# Altium schematic sheet LED_Readout_and_Warning_Lights.SchDoc
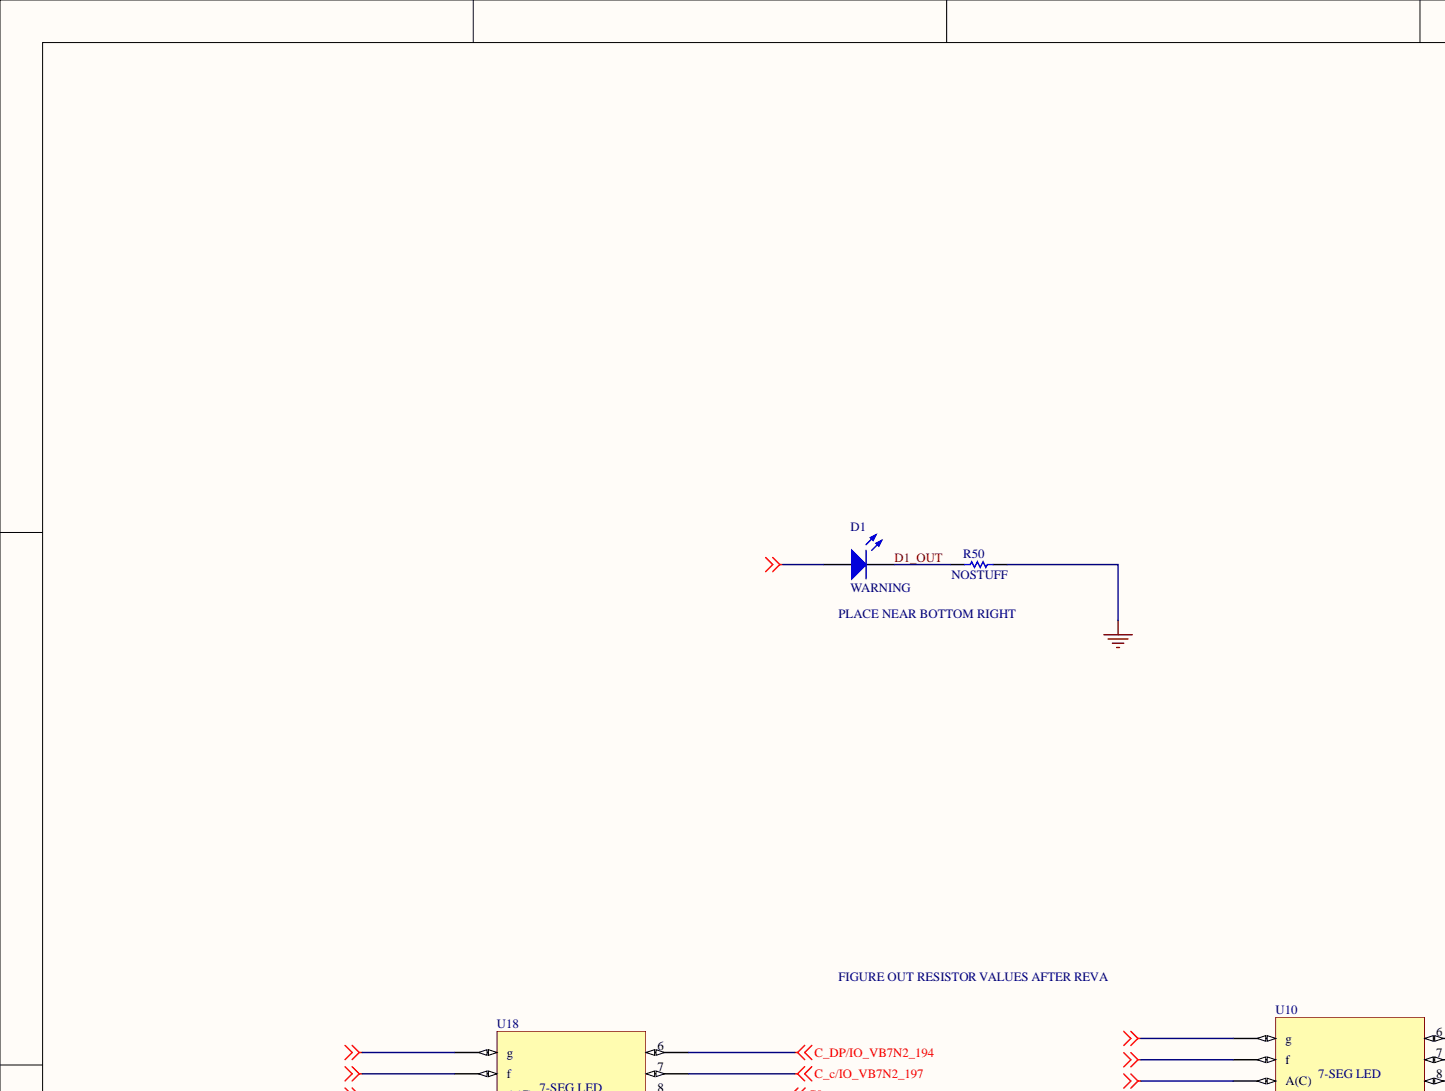
[diagram p0: LED_Readout_and_Warning_Lights.SchDoc, top left]
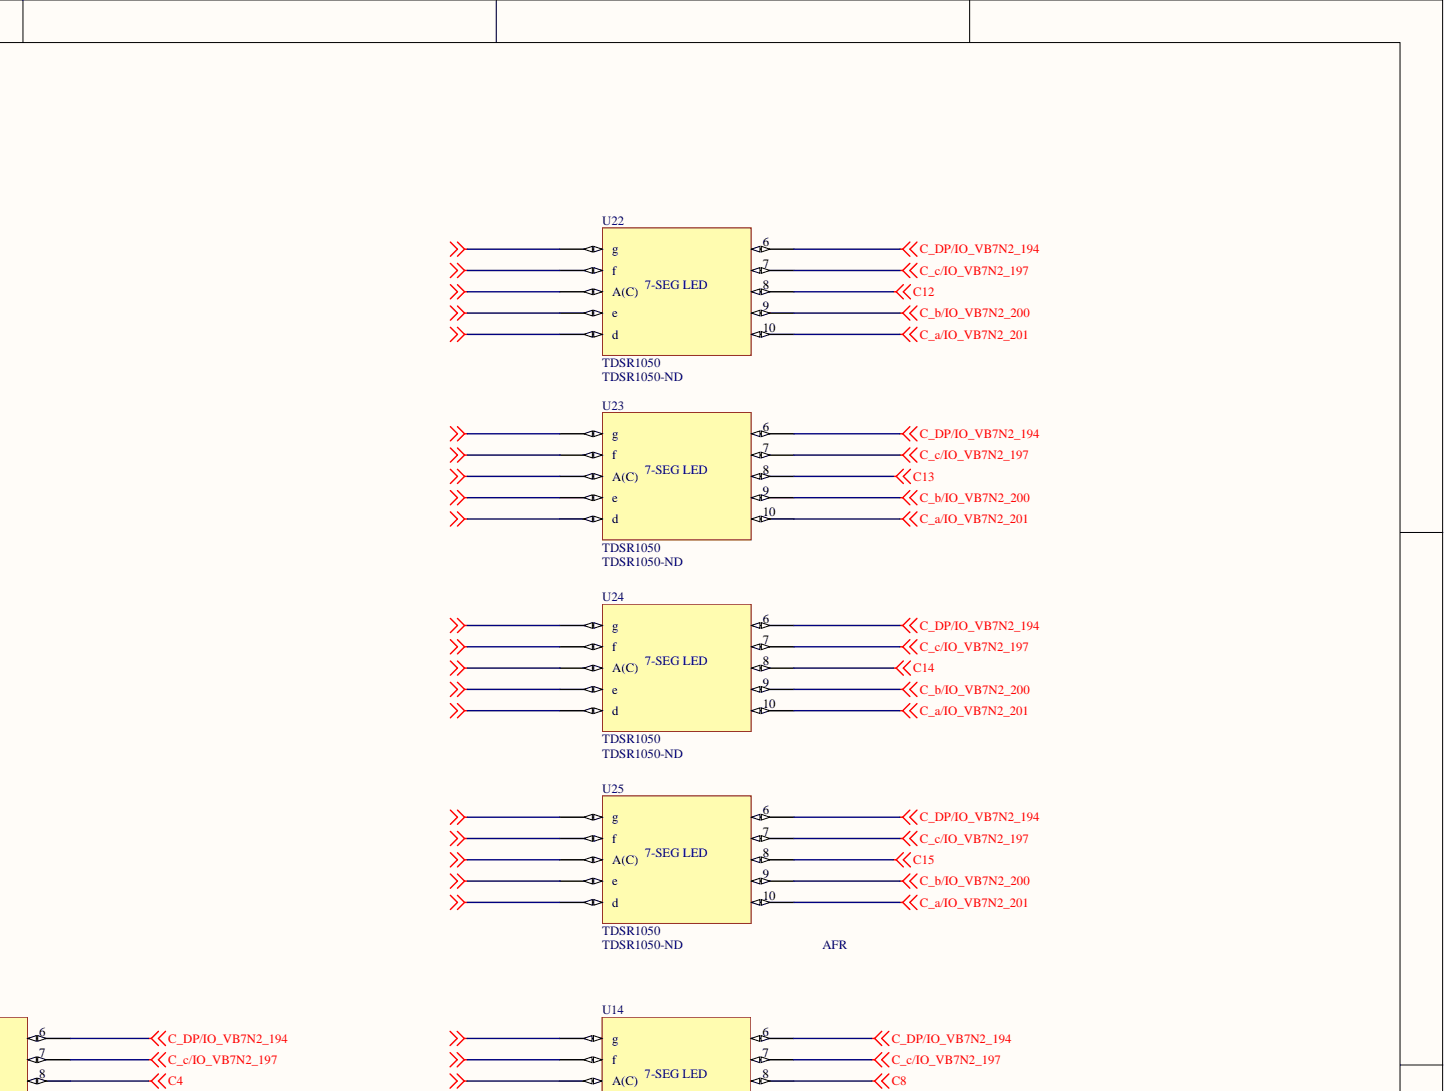
[diagram p1: LED_Readout_and_Warning_Lights.SchDoc, top right]
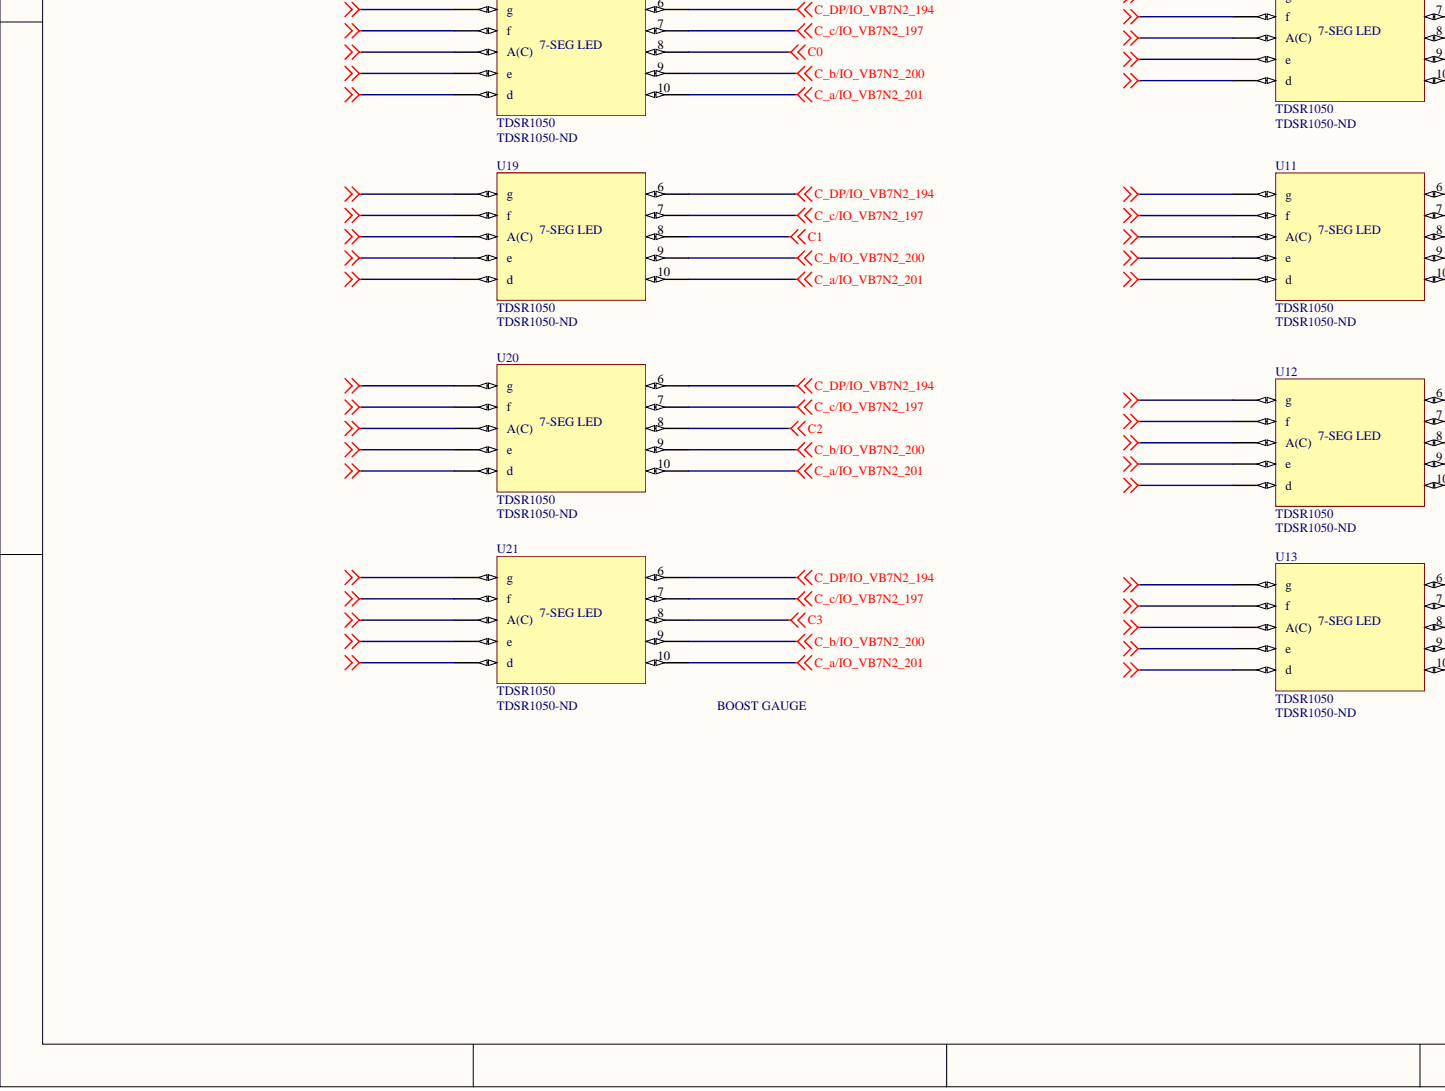
[diagram p2: LED_Readout_and_Warning_Lights.SchDoc, bottom left]
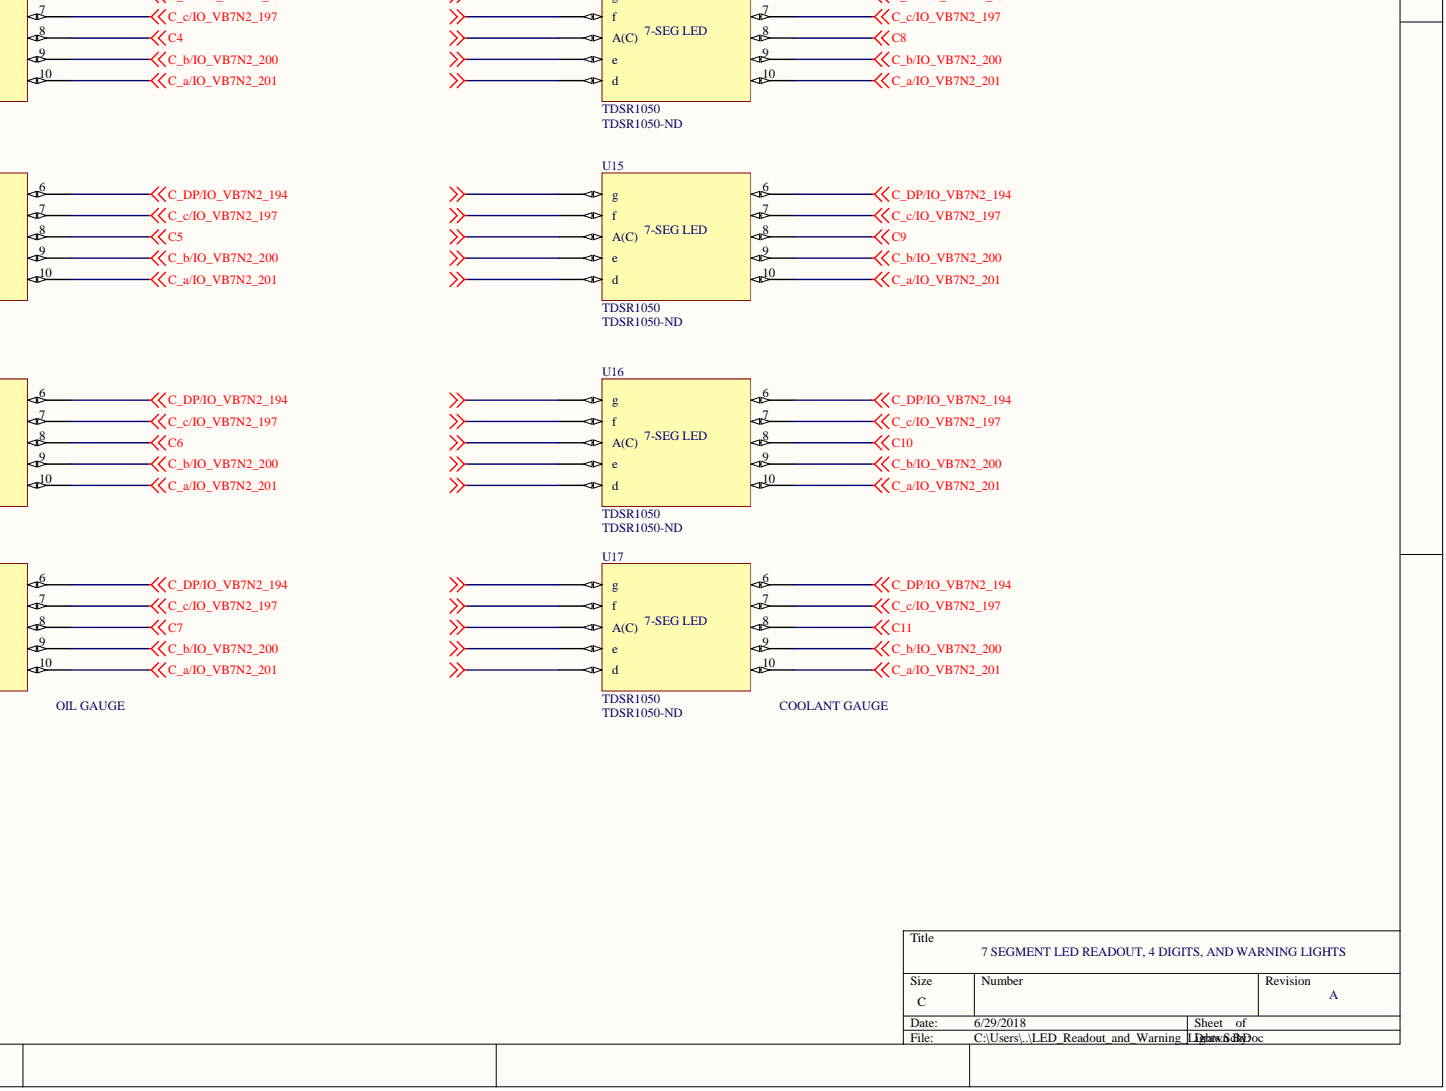
[diagram p3: LED_Readout_and_Warning_Lights.SchDoc, bottom right]

## Parts
D1  WARNING  [DIODE_0603]
R50  value NOSTUFF  [RES_0603]  (hidden comment: Figure out ohmage after RevA)
U10  TDSR1050  Supplier Part Number 1: TDSR1050-ND  [TDSR1050]
U11  TDSR1050  Supplier Part Number 1: TDSR1050-ND  [TDSR1050]
U12  TDSR1050  Supplier Part Number 1: TDSR1050-ND  [TDSR1050]
U13  TDSR1050  Supplier Part Number 1: TDSR1050-ND  [TDSR1050]
U14  TDSR1050  Supplier Part Number 1: TDSR1050-ND  [TDSR1050]
U15  TDSR1050  Supplier Part Number 1: TDSR1050-ND  [TDSR1050]
U16  TDSR1050  Supplier Part Number 1: TDSR1050-ND  [TDSR1050]
U17  TDSR1050  Supplier Part Number 1: TDSR1050-ND  [TDSR1050]
U18  TDSR1050  Supplier Part Number 1: TDSR1050-ND  [TDSR1050]
U19  TDSR1050  Supplier Part Number 1: TDSR1050-ND  [TDSR1050]
U20  TDSR1050  Supplier Part Number 1: TDSR1050-ND  [TDSR1050]
U21  TDSR1050  Supplier Part Number 1: TDSR1050-ND  [TDSR1050]
U22  TDSR1050  Supplier Part Number 1: TDSR1050-ND  [TDSR1050]
U23  TDSR1050  Supplier Part Number 1: TDSR1050-ND  [TDSR1050]
U24  TDSR1050  Supplier Part Number 1: TDSR1050-ND  [TDSR1050]
U25  TDSR1050  Supplier Part Number 1: TDSR1050-ND  [TDSR1050]

## Nets
C0: U18.3(A(C)) U18.8
C1: U19.3(A(C)) U19.8
C2: U20.3(A(C)) U20.8
C3: U21.3(A(C)) U21.8
C4: U10.3(A(C)) U10.8
C5: U11.3(A(C)) U11.8
C6: U12.3(A(C)) U12.8
C7: U13.3(A(C)) U13.8
C8: U14.3(A(C)) U14.8
C9: U15.3(A(C)) U15.8
C10: U16.3(A(C)) U16.8
C11: U17.3(A(C)) U17.8
C12: U22.3(A(C)) U22.8
C13: U23.3(A(C)) U23.8
C14: U24.3(A(C)) U24.8
C15: U25.3(A(C)) U25.8
C_a/IO_VB7N2_201: U10.10 U11.10 U12.10 U13.10 U14.10 U15.10 U16.10 U17.10 U18.10 U19.10 U20.10 U21.10 U22.10 U23.10 U24.10 U25.10
C_b/IO_VB7N2_200: U10.9 U11.9 U12.9 U13.9 U14.9 U15.9 U16.9 U17.9 U18.9 U19.9 U20.9 U21.9 U22.9 U23.9 U24.9 U25.9
C_c/IO_VB7N2_197: U10.7 U11.7 U12.7 U13.7 U14.7 U15.7 U16.7 U17.7 U18.7 U19.7 U20.7 U21.7 U22.7 U23.7 U24.7 U25.7
C_d/IO_VB7N2_196: U10.5(d) U11.5(d) U12.5(d) U13.5(d) U14.5(d) U15.5(d) U16.5(d) U17.5(d) U18.5(d) U19.5(d) U20.5(d) U21.5(d) U22.5(d) U23.5(d) U24.5(d) U25.5(d)
C_DP/IO_VB7N2_194: U10.6 U11.6 U12.6 U13.6 U14.6 U15.6 U16.6 U17.6 U18.6 U19.6 U20.6 U21.6 U22.6 U23.6 U24.6 U25.6
C_e/IO_VB7N0_183: U10.4(e) U11.4(e) U12.4(e) U13.4(e) U14.4(e) U15.4(e) U16.4(e) U17.4(e) U18.4(e) U19.4(e) U20.4(e) U21.4(e) U22.4(e) U23.4(e) U24.4(e) U25.4(e)
C_f/IO_VB7N0_176: U10.2(f) U11.2(f) U12.2(f) U13.2(f) U14.2(f) U15.2(f) U16.2(f) U17.2(f) U18.2(f) U19.2(f) U20.2(f) U21.2(f) U22.2(f) U23.2(f) U24.2(f) U25.2(f)
C_g/IO_VB6N1_171: U10.1(g) U11.1(g) U12.1(g) U13.1(g) U14.1(g) U15.1(g) U16.1(g) U17.1(g) U18.1(g) U19.1(g) U20.1(g) U21.1(g) U22.1(g) U23.1(g) U24.1(g) U25.1(g)
GND: R50.2
WARN/IO_VB6N3_162: D1.1
D1_OUT: D1.2 R50.1

## Library data of the parts
D1 = LED0: Typical INFRARED GaAs LED; Component Kind: Standard; LatestRevisionDate: 08-Jul-2005; LatestRevisionNote: Mech Layer 1 removed.; Library Name: Miscellaneous Devices.IntLib; PackageDescription: LED; 2 Leads; PackageReference: LED-0; Published: 8-Jun-2000; Simulation: LED0; Publisher: Altium Limited
R50 = Res1: Resistor; Component Kind: Standard; LatestRevisionDate: 17-Jul-2002; LatestRevisionNote: Re-released for DXP Platform.; Library Name: Miscellaneous Devices.IntLib; PackageDescription: Axial Device, Thru-Hole; 2 Leads; 0.3 in Pin Spacing; PackageReference: AXIAL-0.3; Published: 8-Jun-2000; Signal Integrity: Res; Simulation: RESISTOR; Publisher: Altium Limited
U10-U25 = TDSR1050: High Intensity Red Low Current 7-Segment Display; Component Kind: Standard; Library Name: schematics.SchLib; Supplier 1: Digi-Key
